# Altium schematic sheet Main.SchDoc
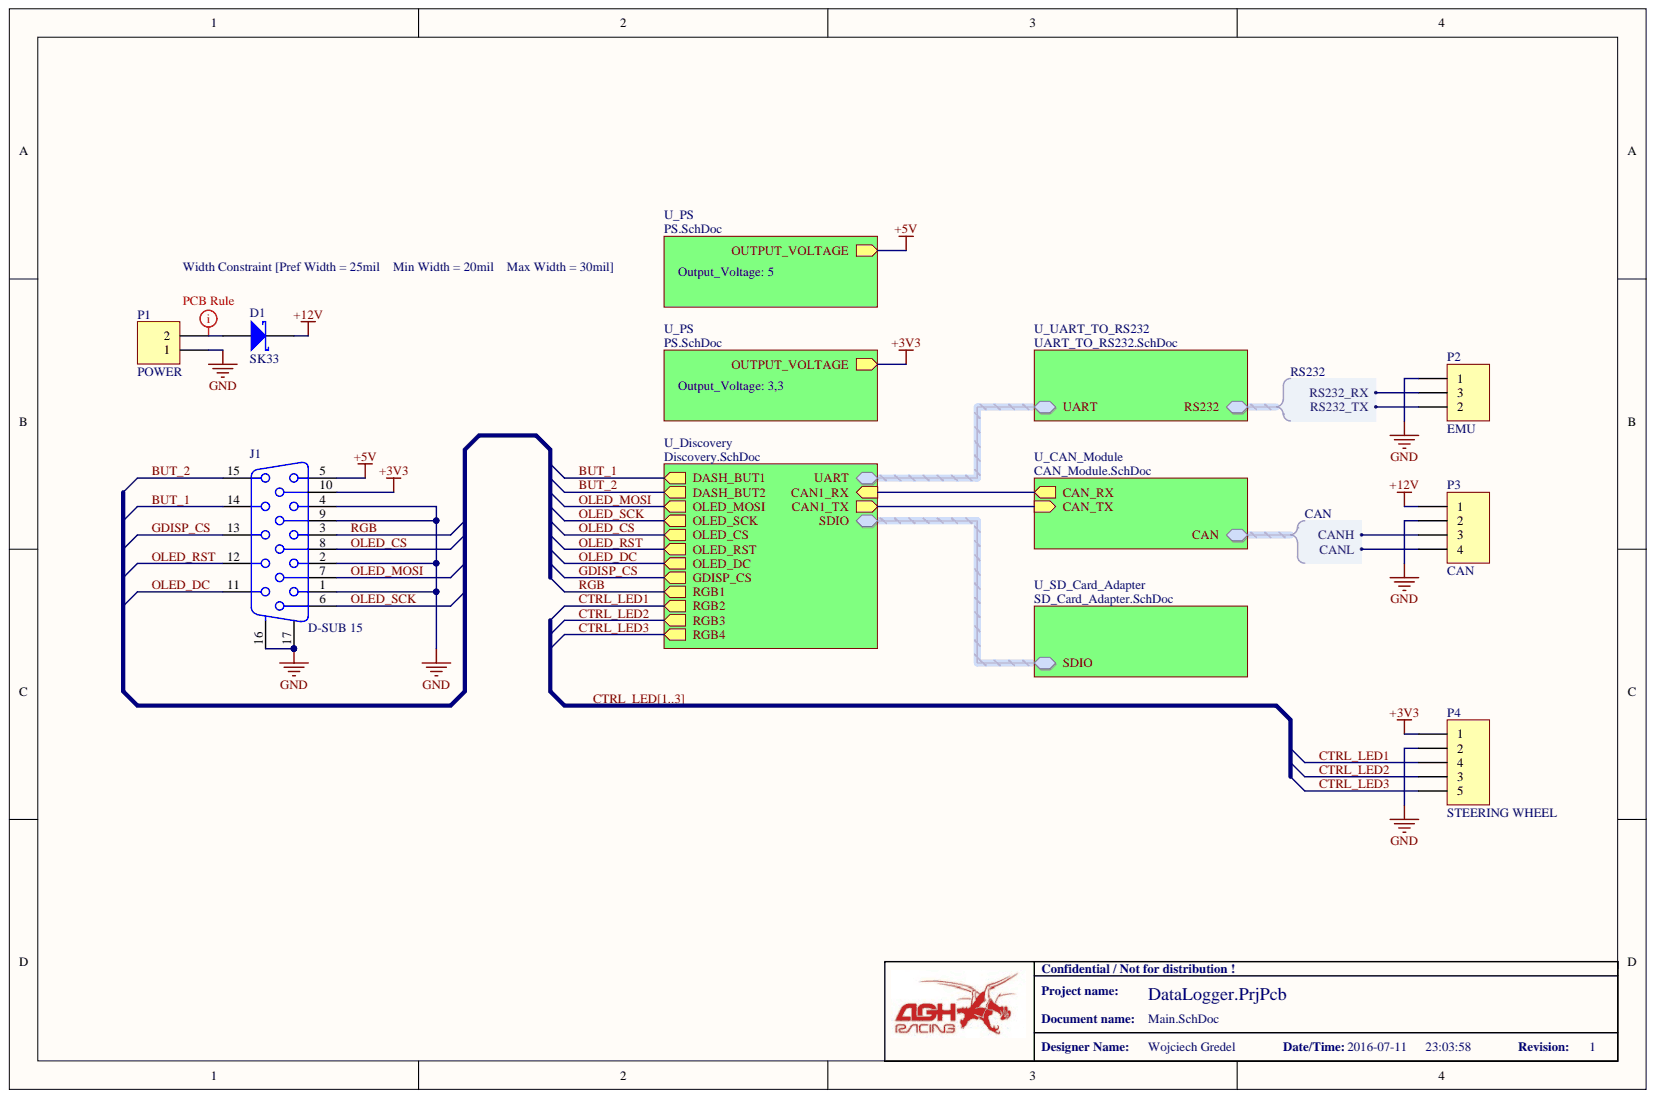
[diagram p0: Main.SchDoc]

## Parts
D1  SK33  [DO214AB_IPC_MD]
J1  D-SUB 15  [Dsub-3H15]
P1  POWER  [HDR_1x2_3_94_LOCKING]
P2  EMU  [HDR_1x3_3_94_LOCKING]
P3  CAN  [HDR_1x4_3_94_LOCKING]
P4  STEERING WHEEL  [HDR_1x5_3_94_LOCKING]

## Nets
+3V3: J1.10 P4.1
+5V: J1.5
+12V: D1.2 P3.1
GND: J1.1 J1.2 J1.4 J1.9 J1.16 J1.17 P1.1 P2.1 P3.2 P4.2
BUT_1: J1.14
BUT_2: J1.15
CTRL_LED1: P4.4  [also labelled CTRL_LED]
CTRL_LED2: P4.3  [also labelled CTRL_LED]
CTRL_LED3: P4.5  [also labelled CTRL_LED]
GDISP_CS: J1.13
OLED_CS: J1.8
OLED_DC: J1.11
OLED_MOSI: J1.7
OLED_RST: J1.12
OLED_SCK: J1.6
RGB: J1.3
NetD1_1: D1.1 P1.2
NetP2_2: P2.2
NetP2_3: P2.3
NetP3_3: P3.3
NetP3_4: P3.4
CAN_RX:
CAN_TX:
N00010:
SDIO.SDIO_CLK:
SDIO.SDIO_CMD:
SDIO.SDIO_CS:
SDIO.SDIO_D0:
UART.UART_RX:
UART.UART_TX:

## Library data of the parts
D1 = SK33: Schottky Diode; Manufacturer: Diotec; Component Kind: Standard; Library Name: DataLogger.SchLib; ManufacturerURL: http://diotec.com/tl_files/diotec/files/pdf/datasheets/sk32; Signal Integrity: D Schottky; Simulation: SKYDIODE; Supplier: TME; Supplier Part Number: SK33-DIO
J1 = D-SUB 15: Receptacle Assembly, 15-Pin, Sim Line Connector; Manufacturer: TE Connectivity; Gender: Receptacle Header; PackageDocument: B; Positions: 15; Component Kind: Standard; DatasheetDocument: 24-Nov-1999; LatestRevisionDate: 17-Jul-2002; LatestRevisionNote: Re-released for DXP Platform.; Orientation: Right Angle; PackageReference: 788574; Published: 15-Jun-2001; Signal Integrity: Connector; Supplier: TME; Supplier Part Number: 1-1734530-3; Library Name: Dashboard.SchLib; Publisher: Altium Limited
P1 = Header 2: Header, 2-Pin; Manufacturer: Joint Tech; Component Kind: Standard; LatestRevisionDate: 17-Jul-2002; LatestRevisionNote: Re-released for DXP Platform.; Library Name: DataLogger.SchLib; Signal Integrity: Connector; Supplier: TME; Supplier Part Number: A3960WV-02P; Publisher: Altium Limited
P2 = MHDR1X3: ST1212 3 pin waterproof connector; Manufacturer: Joint Tech; Component Kind: Standard; LatestRevisionDate: 17-Jul-2002; LatestRevisionNote: Re-released for DXP Platform.; Library Name: DataLogger.SchLib; Signal Integrity: Connector; Supplier: TME; Supplier Part Number: A3960WV-03P; Publisher: Altium Limited
P3 = Header 4: Header, 4-Pin; Manufacturer: Joint Tech; Component Kind: Standard; LatestRevisionDate: 17-Jul-2002; LatestRevisionNote: Re-released for DXP Platform.; Library Name: DataLogger.SchLib; Signal Integrity: Connector; Supplier: TME; Supplier Part Number: A3960WV-04P; Publisher: Altium Limited
P4 = Header 5: Header, 5-Pin; Manufacturer: Joint Tech; Component Kind: Standard; LatestRevisionDate: 17-Jul-2002; LatestRevisionNote: Re-released for DXP Platform.; Library Name: DataLogger.SchLib; Signal Integrity: Connector; Supplier: TME; Supplier Part Number: A3960WV-05P; Publisher: Altium Limited
